# Altium schematic sheet Connectors.SchDoc
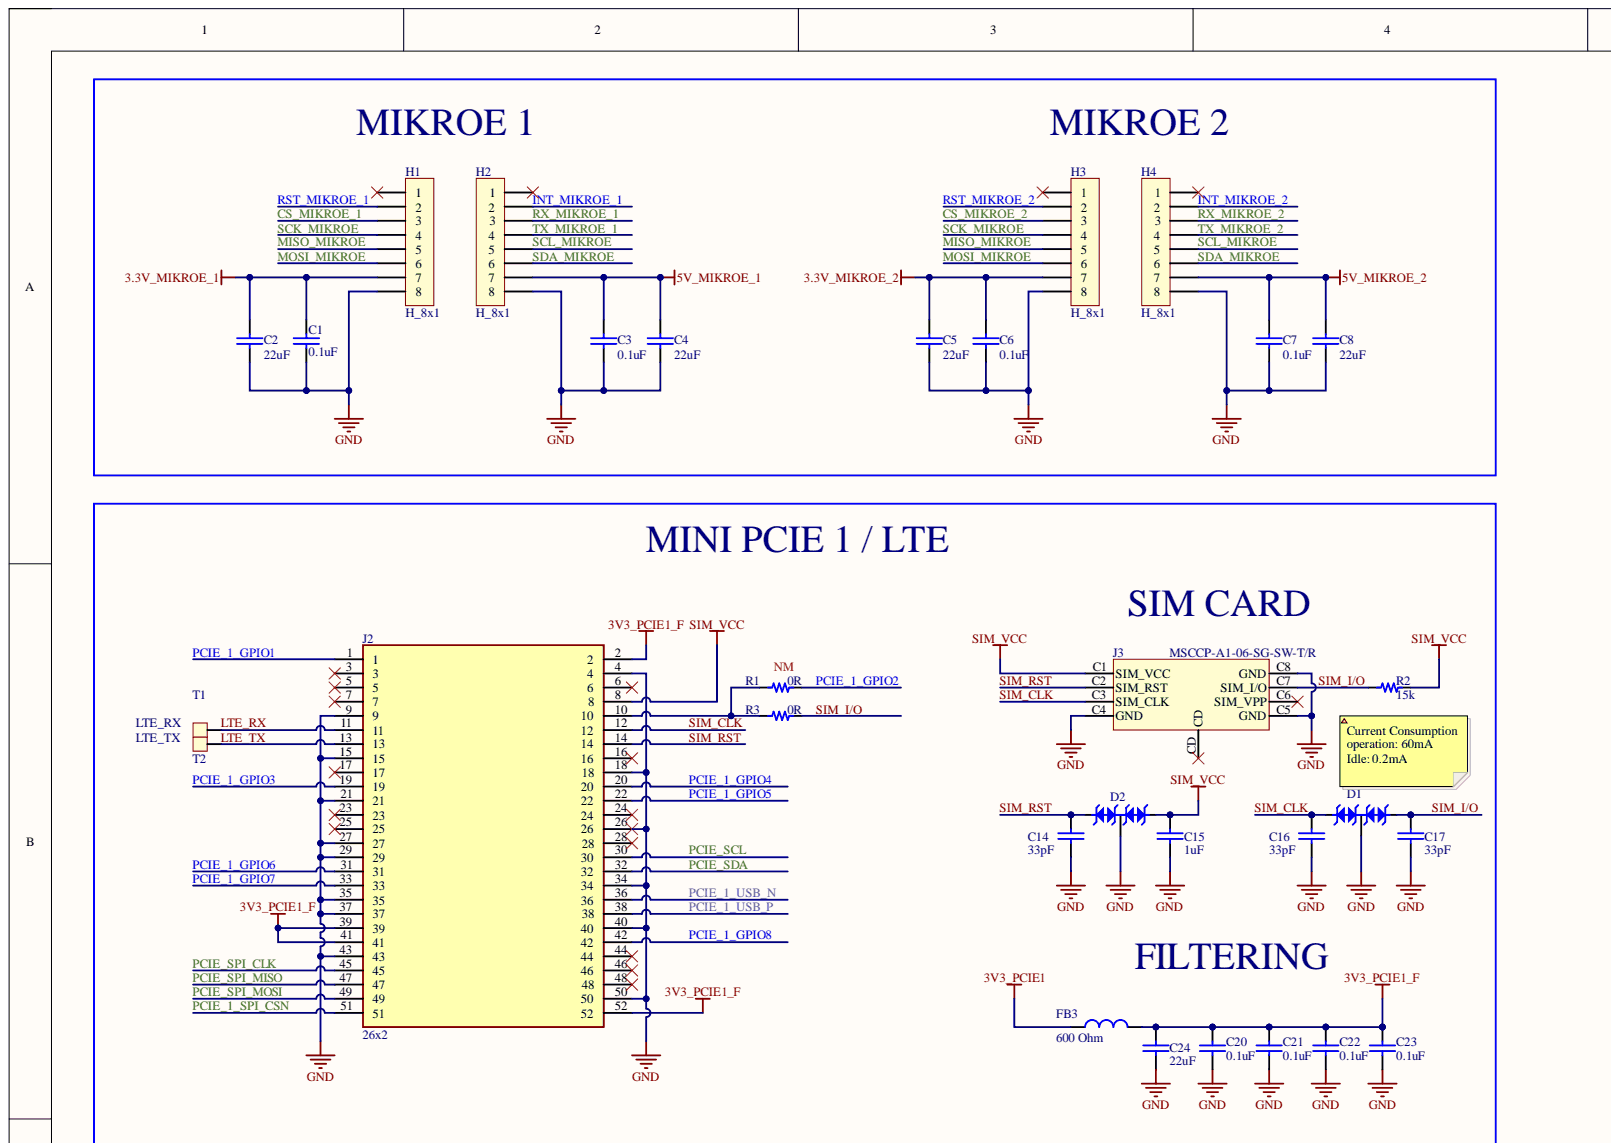
[diagram p0: Connectors.SchDoc, top left]
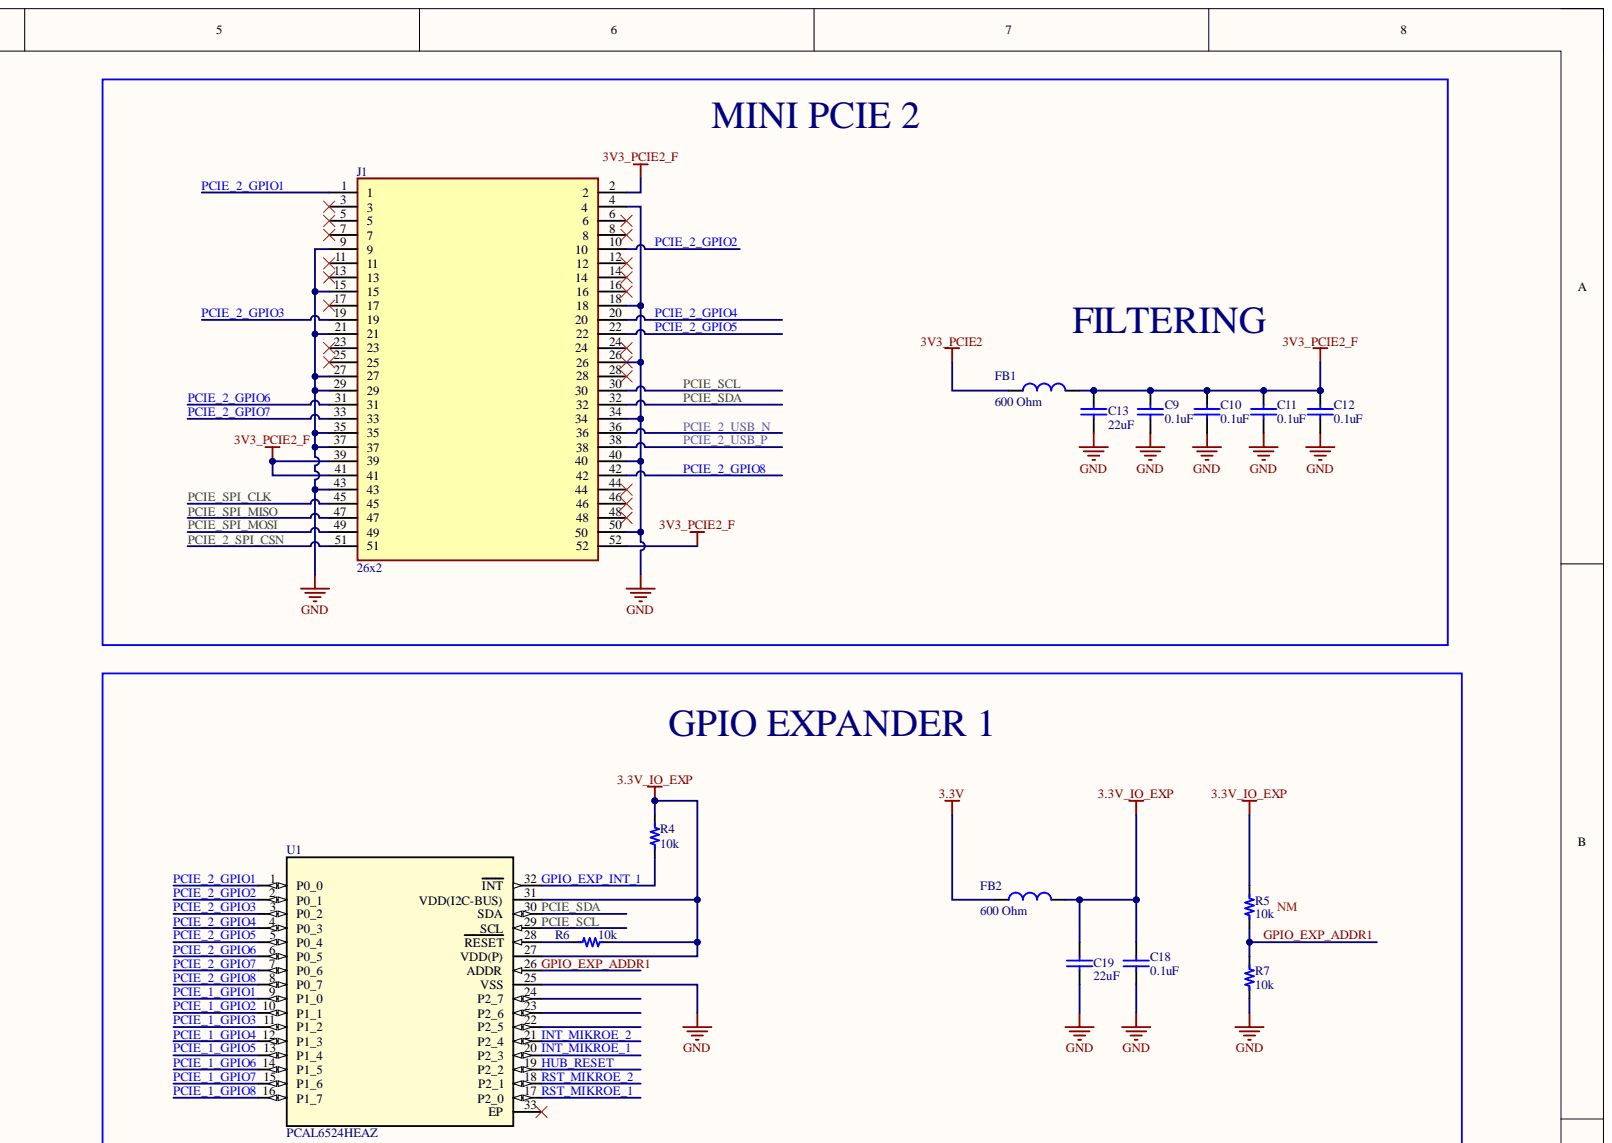
[diagram p1: Connectors.SchDoc, top right]
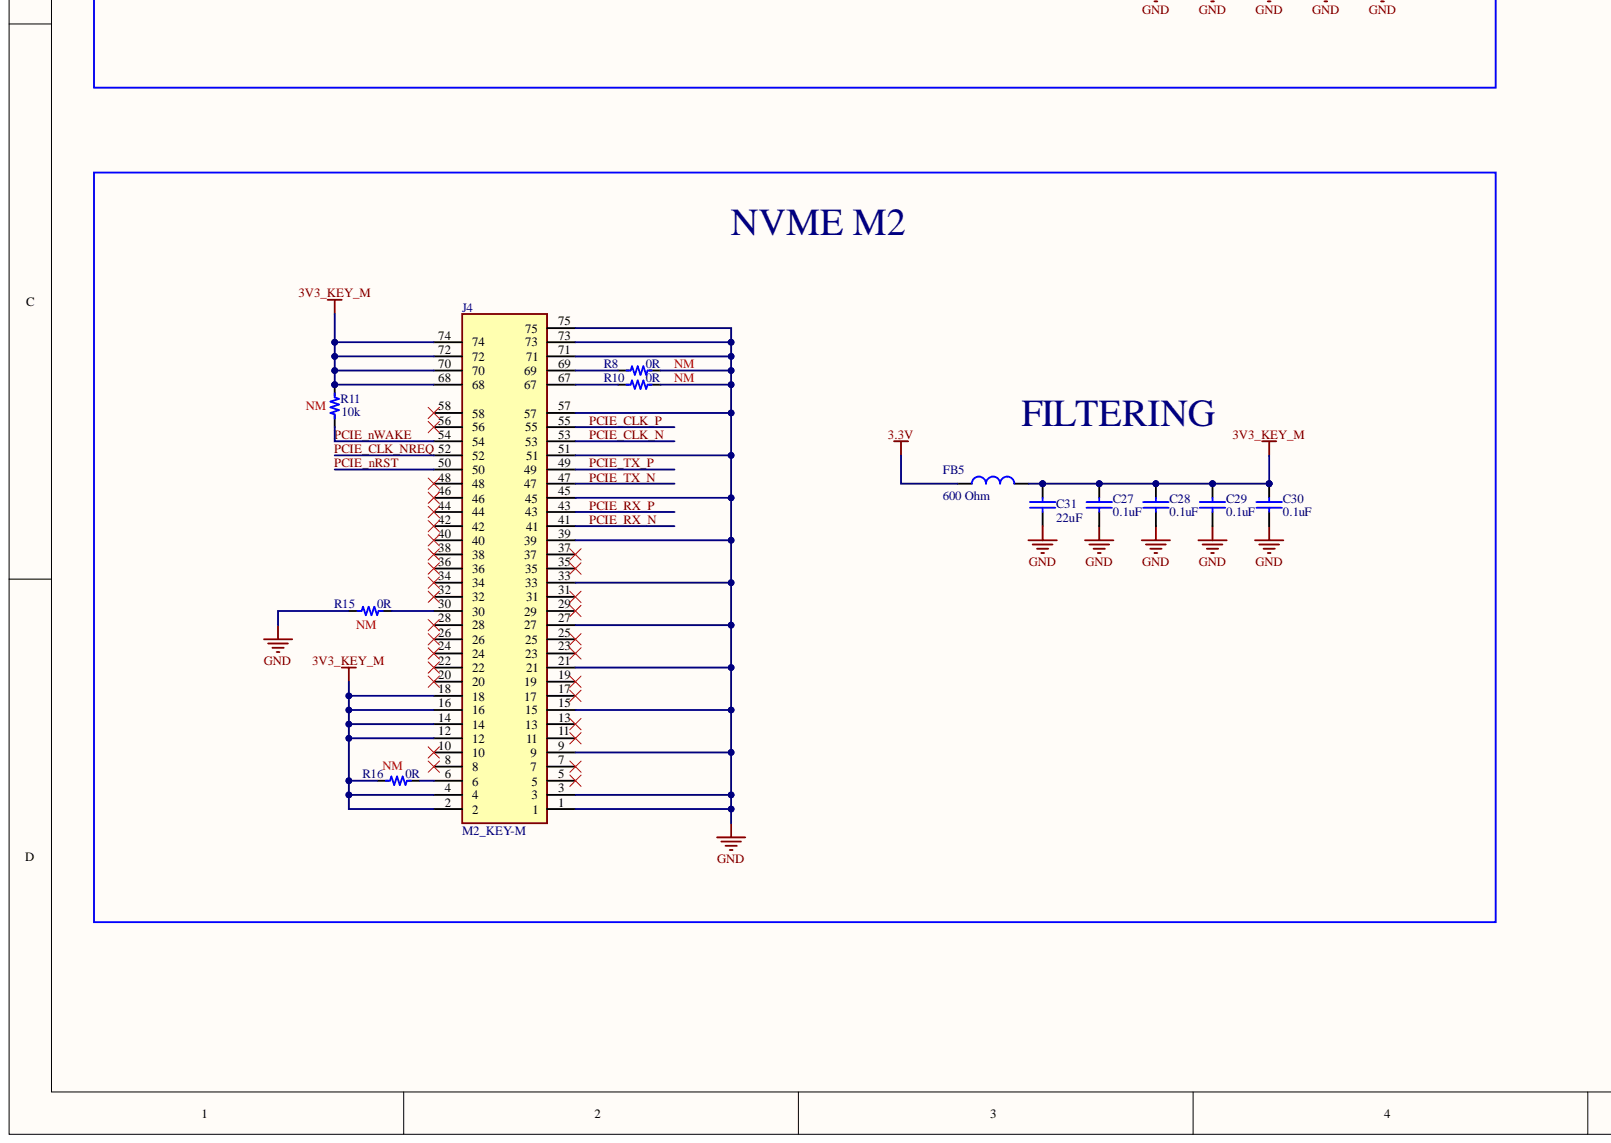
[diagram p2: Connectors.SchDoc, bottom left]
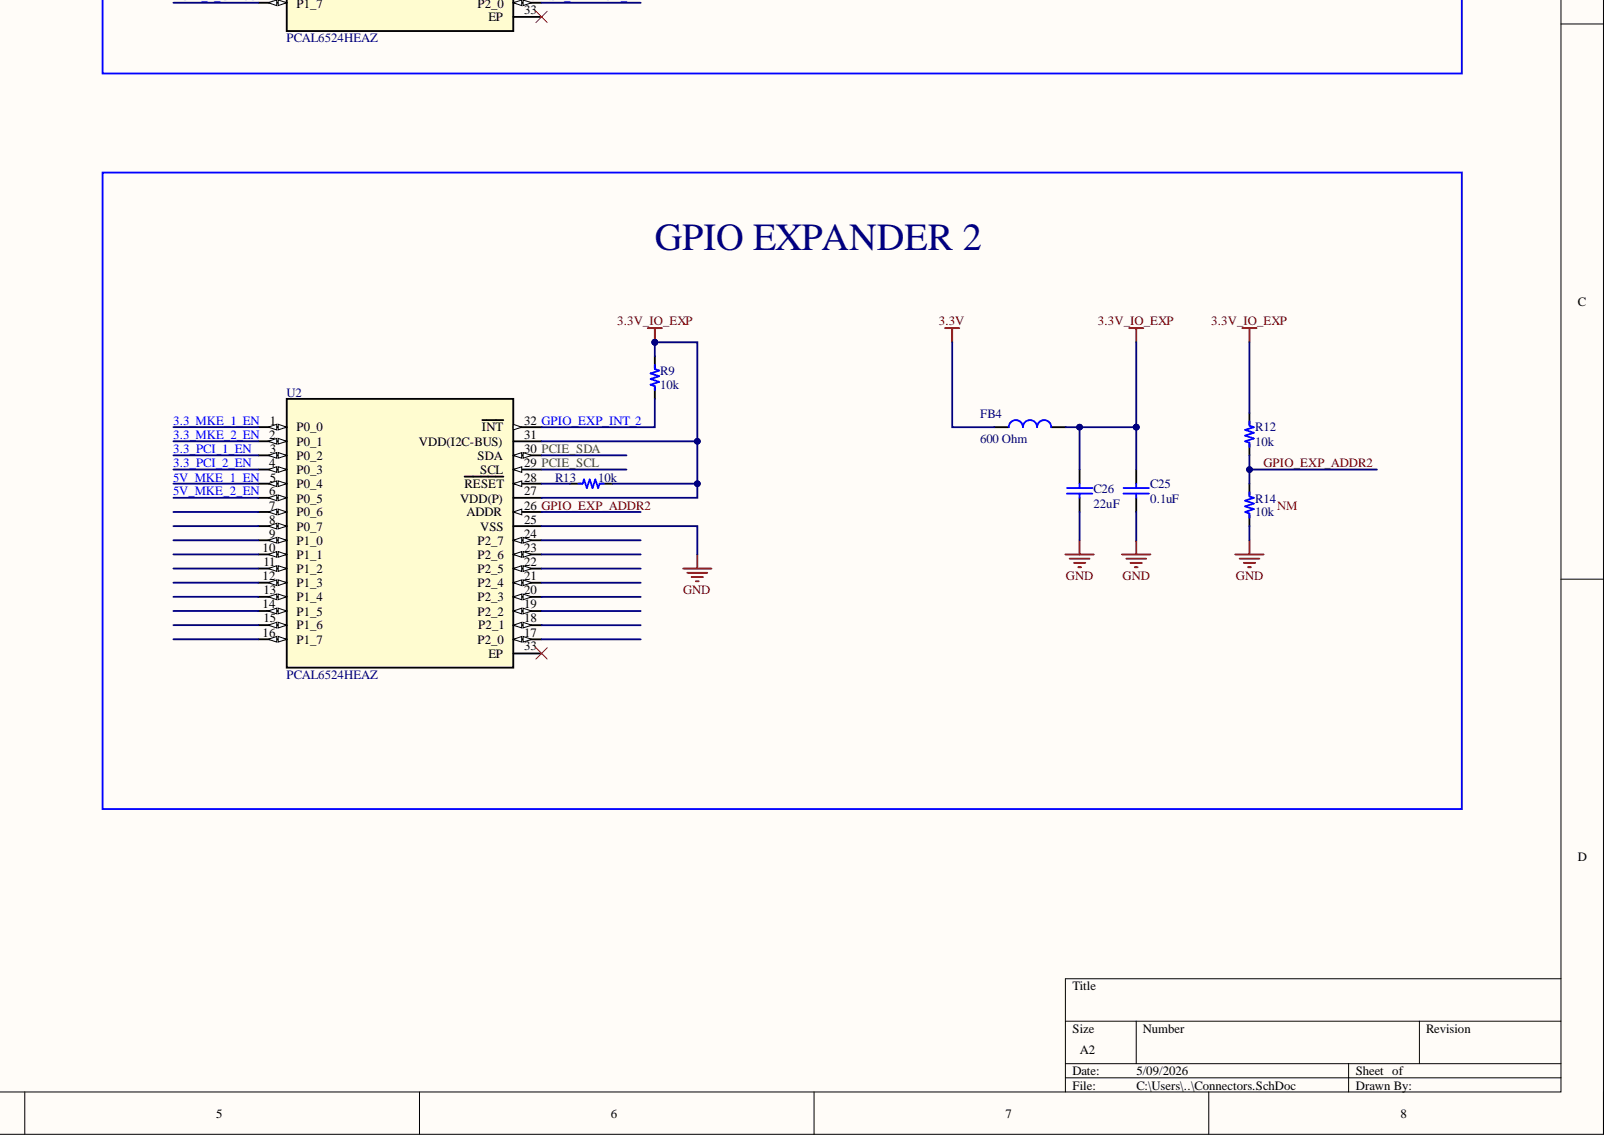
[diagram p3: Connectors.SchDoc, bottom right]

## Parts
C1  value 0.1uF  [0603_1.4mm]  (hidden comment: 0.1uF 100V)
C2  value 22uF  [0603_1.4mm]  (hidden comment: 22uF 10V)
C3  value 0.1uF  [0603_1.4mm]  (hidden comment: 0.1uF 100V)
C4  value 22uF  [0603_1.4mm]  (hidden comment: 22uF 10V)
C5  value 22uF  [0603_1.4mm]  (hidden comment: 22uF 10V)
C6  value 0.1uF  [0603_1.4mm]  (hidden comment: 0.1uF 100V)
C7  value 0.1uF  [0603_1.4mm]  (hidden comment: 0.1uF 100V)
C8  value 22uF  [0603_1.4mm]  (hidden comment: 22uF 10V)
C9  value 0.1uF  [0603]  (hidden comment: 0.1uF 50V)
C10  value 0.1uF  [0603]  (hidden comment: 0.1uF 50V)
C11  value 0.1uF  [0603]  (hidden comment: 0.1uF 50V)
C12  value 0.1uF  [0603]  (hidden comment: 0.1uF 50V)
C13  value 22uF  [0603_1.4mm]  (hidden comment: 22uF 6.3V)
C14  value 33pF  [0603_1.4mm]  (hidden comment: 33pF 50V)
C15  value 1uF  [0603_1.4mm]  (hidden comment: 1uF 25V)
C16  value 33pF  [0603_1.4mm]  (hidden comment: 33pF 50V)
C17  value 33pF  [0603_1.4mm]  (hidden comment: 33pF 50V)
C18  value 0.1uF  [0603]  (hidden comment: 0.1uF 50V)
C19  value 22uF  [0603_1.4mm]  (hidden comment: 22uF 6.3V)
C20  value 0.1uF  [0603]  (hidden comment: 0.1uF 50V)
C21  value 0.1uF  [0603]  (hidden comment: 0.1uF 50V)
C22  value 0.1uF  [0603]  (hidden comment: 0.1uF 50V)
C23  value 0.1uF  [0603]  (hidden comment: 0.1uF 50V)
C24  value 22uF  [0603_1.4mm]  (hidden comment: 22uF 6.3V)
C25  value 0.1uF  [0603]  (hidden comment: 0.1uF 50V)
C26  value 22uF  [0603_1.4mm]  (hidden comment: 22uF 6.3V)
C27  value 0.1uF  [0603]  (hidden comment: 0.1uF 50V)
C28  value 0.1uF  [0603]  (hidden comment: 0.1uF 50V)
C29  value 0.1uF  [0603]  (hidden comment: 0.1uF 50V)
C30  value 0.1uF  [0603]  (hidden comment: 0.1uF 50V)
C31  value 22uF  [0603_1.4mm]  (hidden comment: 22uF 6.3V)
D1  12V 17A  [SOT_23_3]  (value not drawn)
D2  12V 17A  [SOT_23_3]  (value not drawn)
FB1  value 600 Ohm  [1206]  (hidden comment: 600Ohm 2.5A)
FB2  value 600 Ohm  [1206]  (hidden comment: 600Ohm 2.5A)
FB3  value 600 Ohm  [1206]  (hidden comment: 600Ohm 2.5A)
FB4  value 600 Ohm  [1206]  (hidden comment: 600Ohm 2.5A)
FB5  value 600 Ohm  [1206]  (hidden comment: 600Ohm 2.5A)
H1  H_8x1  [H_8x1_TH_100mil]  (no BOM)
H2  H_8x1  [H_8x1_TH_100mil]  (no BOM)
H3  H_8x1  [H_8x1_TH_100mil]  (no BOM)
H4  H_8x1  [H_8x1_TH_100mil]  (no BOM)
J1  26x2  [CON_26X2_SMD_0.8MM]
J2  26x2  [CON_26X2_SMD_0.8MM]
J3  MSCCP-A1-06-SG-SW-T/R  [CON_MICRO_SIMCARD]
J4  M2_KEY-M  [CON_M2_KEY_M]
R1  value 0R  Usage: NM  [0603_1.4mm]  (hidden comment: 0R 1/10W)
R2  value 15k  [0603_1.4mm]  (hidden comment: 15k 1/10W)
R3  value 0R  [0603_1.4mm]  (hidden comment: 0R 1/10W)
R4  value 10k  [0603_1.4mm]  (hidden comment: 10k 1/10W)
R5  value 10k  Usage: NM  [0603_1.4mm]  (hidden comment: 10k 1/10W)
R6  value 10k  [0603_1.4mm]  (hidden comment: 10k 1/10W)
R7  value 10k  [0603_1.4mm]  (hidden comment: 10k 1/10W)
R8  value 0R  Usage: NM  [0603_1.4mm]  (hidden comment: 0R 1/10W)
R9  value 10k  [0603_1.4mm]  (hidden comment: 10k 1/10W)
R10  value 0R  Usage: NM  [0603_1.4mm]  (hidden comment: 0R 1/10W)
R11  value 10k  Usage: NM  [0603_1.4mm]  (hidden comment: 10k 1/10W)
R12  value 10k  [0603_1.4mm]  (hidden comment: 10k 1/10W)
R13  value 10k  [0603_1.4mm]  (hidden comment: 10k 1/10W)
R14  value 10k  Usage: NM  [0603_1.4mm]  (hidden comment: 10k 1/10W)
R15  value 0R  Usage: NM  [0603_1.4mm]  (hidden comment: 0R 1/10W)
R16  value 0R  Usage: NM  [0603_1.4mm]  (hidden comment: 0R 1/10W)
T1  LTE_RX  [TP_TH_30mil]  (no BOM)
T2  LTE_TX  [TP_TH_30mil]  (no BOM)
U1  PCAL6524HEAZ  [32-UFQFN_EP]
U2  PCAL6524HEAZ  [32-UFQFN_EP]

## Nets
3.3V: FB2.1 FB4.1 FB5.1
3.3V_IO_EXP: C18.1 C19.1 C25.1 C26.1 FB2.2 FB4.2 R4.1 R5.1 R6.2 R9.1 R12.1 R13.2 U1.27(VDD(P)) U1.31(VDD(I2C-BUS)) U2.27(VDD(P)) U2.31(VDD(I2C-BUS))
3.3V_MIKROE_1: C1.1 C2.2 H1.7
3.3V_MIKROE_2: C5.2 C6.1 H3.7
3V3_KEY_M: C27.1 C28.1 C29.1 C30.1 C31.1 FB5.2 J4.2 J4.4 J4.12 J4.14 J4.16 J4.18 J4.68 J4.70 J4.72 J4.74 R11.1 R16.1
3V3_PCIE1: FB3.1
3V3_PCIE1_F: C20.1 C21.1 C22.1 C23.1 C24.1 FB3.2 J2.2 J2.39 J2.41 J2.52
3V3_PCIE2: FB1.1
3V3_PCIE2_F: C9.1 C10.1 C11.1 C12.1 C13.1 FB1.2 J1.2 J1.39 J1.41 J1.52
5V_MIKROE_1: C3.1 C4.2 H2.7
5V_MIKROE_2: C7.1 C8.2 H4.7
GND: C1.2 C2.1 C3.2 C4.1 C5.1 C6.2 C7.2 C8.1 C9.2 C10.2 C11.2 C12.2 C13.2 C14.2 C15.2 C16.2 C17.2 C18.2 C19.2 C20.2 C21.2 C22.2 C23.2 C24.2 C25.2 C26.2 C27.2 C28.2 C29.2 C30.2 C31.2 D1.3 D2.3 H1.8 H2.8 H3.8 H4.8 J1.4 J1.9 J1.15 J1.18 J1.21 J1.26 J1.27 J1.29 J1.34 J1.35 J1.37 J1.40 J1.43 J1.50 J2.4 J2.9 J2.15 J2.18 J2.21 J2.26 J2.27 J2.29 J2.34 J2.35 J2.37 J2.40 J2.43 J2.50 J3.C4(GND) J3.C5(GND) J3.C8(GND) J4.1 J4.3 J4.9 J4.15 J4.21 J4.27 J4.33 J4.39 J4.45 J4.51 J4.57 J4.71 J4.73 J4.75 R7.2 R8.2 R10.2 R14.2 R15.1 U1.25(VSS) U2.25(VSS)
SIM_VCC: C15.1 D2.2 J2.8 J3.C1(SIM_VCC) R2.1
3.3_MKE_1_EN: U2.1(P0_0)
3.3_MKE_2_EN: U2.2(P0_1)
3.3_PCI_1_EN: U2.3(P0_2)
3.3_PCI_2_EN: U2.4(P0_3)
5V_MKE_1_EN: U2.5(P0_4)
5V_MKE_2_EN: U2.6(P0_5)
CS_MIKROE_1: H1.3
CS_MIKROE_2: H3.3
GPIO_EXP_ADDR1: R5.2 R7.1 U1.26(ADDR)
GPIO_EXP_ADDR2: R12.2 R14.1 U2.26(ADDR)
GPIO_EXP_INT_1: R4.2 U1.32(INT)
GPIO_EXP_INT_2: R9.2 U2.32(INT)
HUB_RESET: U1.19(P2_2)
INT_MIKROE_1: H2.2 U1.20(P2_3)
INT_MIKROE_2: H4.2 U1.21(P2_4)
LTE_RX: J2.11 T1.1
LTE_TX: J2.13 T2.1
MISO_MIKROE: H1.5 H3.5
MOSI_MIKROE: H1.6 H3.6
PCIE_1_GPIO1: J2.1 U1.9(P1_0)
PCIE_1_GPIO2: R1.2 U1.10(P1_1)
PCIE_1_GPIO3: J2.19 U1.11(P1_2)
PCIE_1_GPIO4: J2.20 U1.12(P1_3)
PCIE_1_GPIO5: J2.22 U1.13(P1_4)
PCIE_1_GPIO6: J2.31 U1.14(P1_5)
PCIE_1_GPIO7: J2.33 U1.15(P1_6)
PCIE_1_GPIO8: J2.42 U1.16(P1_7)
PCIE_1_SPI_CSN: J2.51
PCIE_1_USB_N: J2.36
PCIE_1_USB_P: J2.38
PCIE_2_GPIO1: J1.1 U1.1(P0_0)
PCIE_2_GPIO2: J1.10 U1.2(P0_1)
PCIE_2_GPIO3: J1.19 U1.3(P0_2)
PCIE_2_GPIO4: J1.20 U1.4(P0_3)
PCIE_2_GPIO5: J1.22 U1.5(P0_4)
PCIE_2_GPIO6: J1.31 U1.6(P0_5)
PCIE_2_GPIO7: J1.33 U1.7(P0_6)
PCIE_2_GPIO8: J1.42 U1.8(P0_7)
PCIE_2_SPI_CSN: J1.51
PCIE_2_USB_N: J1.36
PCIE_2_USB_P: J1.38
PCIE_CLK_N: J4.53
PCIE_CLK_NREQ: J4.52
PCIE_CLK_P: J4.55
PCIE_nRST: J4.50
PCIE_nWAKE: J4.54 R11.2
PCIE_RX_N: J4.41
PCIE_RX_P: J4.43
PCIE_SCL: J1.30 J2.30 U1.29(SCL) U2.29(SCL)
PCIE_SDA: J1.32 J2.32 U1.30(SDA) U2.30(SDA)
PCIE_SPI_CLK: J1.45 J2.45
PCIE_SPI_MISO: J1.47 J2.47
PCIE_SPI_MOSI: J1.49 J2.49
PCIE_TX_N: J4.47
PCIE_TX_P: J4.49
RST_MIKROE_1: H1.2 U1.17(P2_0)
RST_MIKROE_2: H3.2 U1.18(P2_1)
RX_MIKROE_1: H2.3
RX_MIKROE_2: H4.3
SCK_MIKROE: H1.4 H3.4
SCL_MIKROE: H2.5 H4.5
SDA_MIKROE: H2.6 H4.6
SIM_CLK: C16.1 D1.1 J2.12 J3.C3(SIM_CLK)
SIM_I/O: C17.1 D1.2 J3.C7(SIM_I/O) R2.2 R3.2
SIM_RST: C14.1 D2.1 J2.14 J3.C2(SIM_RST)
TX_MIKROE_1: H2.4
TX_MIKROE_2: H4.4
NetJ2_10: J2.10 R1.1 R3.1
NetJ4_6: J4.6 R16.2
NetJ4_30: J4.30 R15.2
NetJ4_67: J4.67 R10.1
NetJ4_69: J4.69 R8.1
NetR6_1: R6.1 U1.28(RESET)
NetR13_1: R13.1 U2.28(RESET)

Not connected: H1 1; H2 1; H3 1; H4 1; J1 3 5 6 7 8 11 12 13 14 16 17 23 24 25 28 44 46 48; J2 3 5 6 7 16 17 23 24 25 28 44 46 48; J3 C6(SIM_VPP) CD; J4 5 7 8 10 11 13 17 19 20 22 23 24 25 26 28 29 31 32 34 35 36 37 38 40 42 44 46 48 56 58; U1 22(P2_5) 23(P2_6) 24(P2_7) 33(EP); U2 7(P0_6) 8(P0_7) 9(P1_0) 10(P1_1) 11(P1_2) 12(P1_3) 13(P1_4) 14(P1_5) 15(P1_6) 16(P1_7) 17(P2_0) 18(P2_1) 19(P2_2) 20(P2_3) 21(P2_4) 22(P2_5) 23(P2_6) 24(P2_7) 33(EP)

## Library data of the parts
C1 C3 C6 C7 = Cap_0.1uF_0603_100V: CAP CER 0.1UF 100V X7R 0603; Manufacturer: Samsung Electro-Mechanics; Manufacturer Part Number: CL10B104KC8NNNC; Component Kind: Standard; ComponentLink1Description: Digi-Key; ComponentLink1URL: https://www.digikey.com/en/products/detail/samsung-electro-mechanics/CL10B104KC8NNNC/5961291; Last modified date: 20250321; Library Name: Capacitors.IntLib; Supplier 1: Digi-Key; Supplier Part Number 1: 1276-6807-1-ND
C2 C4 C5 C8 = Cap_22uF_0603_10V: CAP CER 22UF 10V X5R 0603; Manufacturer: Murata Electronics North America; Manufacturer Part Number: GRM188R61A226ME15D; Component Kind: Standard; ComponentLink1Description: Digi-key; ComponentLink1URL: https://www.digikey.com/product-detail/en/murata-electronics-north-america/GRM188R61A226ME15D/490-10476-1-ND/5026394; Last modified date: 20250321; Library Name: Capacitors.IntLib; Supplier 1: Digi-Key; Supplier Part Number 1: 490-10476-1-ND
C9-C12 C18 C20-C23 C25 C27-C30 = Cap_0.1uF_0603_50V: CAP CER 0.1UF 50V X7R 0603; Manufacturer: Samsung Electro-Mechanics; Manufacturer Part Number: CL10B104JB8NNNC; Titoma stock: TD-TE-Reel; Component Kind: Standard; ComponentLink1Description: Digi-key; ComponentLink1URL: https://www.digikey.com/en/products/detail/samsung-electro-mechanics/CL10B104JB8NNNC/3886691?s=N4IgTCBcDaIIxgOwDYC0cAMBmL7UDkAREAXQF8g; Last modified date: 20210309; Library Name: Capacitors.IntLib; Supplier 1: Digi-Key; Supplier Part Number 1: 1276-1033-1-ND; Last modified by: C-D Viveros
C13 C19 C24 C26 C31 = Cap_22uF_0603_6.3V: CAP CER 22UF 6.3V X5R 0603; Manufacturer: Murata; Manufacturer Part Number: GRM188R60J226MEA0D; Component Kind: Standard; ComponentLink1Description: Digi-key; ComponentLink1URL: https://www.digikey.com/products/en?keywords=490-7611-1-ND; Last modified date: 20200505; Library Name: Capacitors.IntLib; Supplier 1: Digi-Key; Supplier Part Number 1: 490-7611-1-ND; Last modified by: N-A.Potosi
C14 C16 C17 = Cap_33pF_0603_50V: CAP CER 33PF 50V C0G/NP0 0603; Manufacturer: Walsin Technologies; Manufacturer Part Number: 0603N330J500CT; Titoma stock: TD-TE-Reel; Component Kind: Standard; ComponentLink1Description: Digi-Key; ComponentLink1URL: https://www.digikey.com/en/products/detail/walsin-technology-corporation/0603N330J500CT/6707550?s=N4IgTCBcDaIIxgJxgLRwGwBYCsaUDkAREAXQF8g; Last modified date: 20210512; Library Name: Capacitors.IntLib; Supplier 1: Digi-Key; Supplier Part Number 1: 1292-1645-1-ND; Last modified by: C-A.Serna.A
C15 = Cap_1uF_0603_25V: CAP CER 1UF 25V X7R 0603; Manufacturer: Samsung Electro-Mechanics; Manufacturer Part Number: CL10B105KA8VPNC; Titoma stock: TD-TE-Reel; Component Kind: Standard; ComponentLink1Description: Digi-Key; ComponentLink1URL: https://www.digikey.com/en/products/detail/samsung-electro-mechanics/CL10B105KA8VPNC/5961101?s=N4IgTCBcDaIIxgOwDYC0zl0auqByAIiALoC%2BQA; Last modified date: 20210511; Library Name: Capacitors.IntLib; Supplier 1: Digi-Key; Supplier Part Number 1: 1276-6617-1-ND; Last modified by: C-A.Serna.A
D1 D2 = TVS_12V_2CH-BI_SOT-23-3: TVS DIODE 12V/7V 26V/12V SOT23-3; Manufacturer: Semtech Corporation; Manufacturer Part Number: SM712.TCT; Component Kind: Standard; ComponentLink1Description: Digi-Key; ComponentLink1URL: https://www.digikey.com/en/products/detail/semtech-corporation/SM712-TCT/1000933?s=N4IgTCBcDaIMoFkDsBGMBhAKgWgHIBEQBdAXyA; Last modified date: 20210208; Library Name: Circuit_protection.IntLib; Supplier 1: Digi-Key; Supplier Part Number 1: SM712CT-ND; Last modified by: C-D Viveors
FB1-FB5 = FB_600_1206_2.5A: FERRITE BEAD 600 OHM 1206 1LN; Manufacturer: Würth Elektronik; Manufacturer Part Number: 742792118; Component Kind: Standard; ComponentLink1Description: Digi-key; ComponentLink1URL: https://www.digikey.com/products/en?keywords=732-4653-1-ND; Last modified date: 20210806; Library Name: Filters.IntLib; Supplier 1: Digi-key; Supplier Part Number 1: 732-4653-1-ND; Last modified by: N-A.Potosi
H1-H4 = H_Male_8x1_TH_100mil: Debugging male pin; Last modified date: 20250321; Library Name: Connectors.IntLib
J1 J2 = Con_26x2_SMD_0.8mm_PCIEXP_MINI: CONN PCI EXP MINI FEMALE 52POS; Manufacturer: TE Connectivity AMP Connectors; Manufacturer Part Number: 1775862-2; Component Kind: Standard; ComponentLink1Description: Digikey; ComponentLink1URL: https://www.digikey.com/en/products/detail/te-connectivity-amp-connectors/1775862-2/5430585?s=N4IgTCBcDaIIIEYAsYwHYDMBhAKgWgDkAREAXQF8g; Last modified date: 20250321; Library Name: Connectors.IntLib; Supplier 1: Digi-key; Supplier Part Number 1: A142273CT-ND
J3 = Con_Micro_SimCard: MINI SIM CARD CONNECTOR; Manufacturer: Adam Tech; Component Kind: Standard; ComponentLink1Description: Digikey; ComponentLink1URL: https://www.digikey.com/en/products/detail/adam-tech/MSCCP-A1-06-SG-SW-T-R/11689344?s=N4IgTCBcDa4AwFYDsBaAsgZQMJYAooEEBGFOANhQwHFKB1FAFQHoAlLBlAOQBEQBdAL5A; Last modified date: 20210723; Library Name: Connectors.IntLib; Manufacturer Part Number: MSCCP-A1-06-SG-SW-T/R; Supplier 1: Digikey; Supplier Part Number 1: 2057-MSCCP-A1-06-SG-SW-T/RCT-ND; Last modified by: J-E.Pachon
J4 = CON_M2_KEY_M: M.2 R/A M KEY 3.20H; Manufacturer: Amphenol ICC (FCI); Manufacturer Part Number: MDT320M01004; Component Kind: Standard; Last modified date: 20260406; Library Name: Connectors.IntLib; Supplier 1: Digi-Key; Supplier Part Number 1: 609-MDT320M01004CT-ND
R1 R3 R8 R10 R15 R16 = Res_0R_0603_1/10W: RES SMD 0 OHM JUMPER 1/10W 0603; Manufacturer: Panasonic Electronic Components; Manufacturer Part Number: ERJ-3GEY0R00V; Component Kind: Standard; ComponentLink1Description: Digi-key; ComponentLink1URL: https://www.digikey.com/products/en?keywords=P0.0GCT-ND; Last modified date: 20250321; Supplier 1: Digi-Key; Supplier Part Number 1: P0.0GCT-ND; Library Name: Resistors-potenciometers.IntLib
R2 = Res_15k_0603_1/10W: RES SMD 15K OHM 1% 1/10W 0603; Manufacturer: Yageo; Manufacturer Part Number: RC0603FR-0715KL; Titoma stock: TD-TE-Reel; Component Kind: Standard; ComponentLink1Description: Digi-Key; ComponentLink1URL: https://www.digikey.com/product-detail/en/yageo/RC0603FR-0715KL/311-15.0KHRTR-ND/726953; Last modified date: 20210513; Supplier 1: Digi-Key; Supplier Part Number 1: 311-15.0KHRCT-ND; Last modified by: J-E.Pachon; Library Name: Resistors-potenciometers.IntLib
R4-R7 R9 R11-R14 = Res_10k_0603_1/10W: RES SMD 10K OHM 1% 1/10W 0603; Manufacturer: Samsung Electro-Mechanics; Manufacturer Part Number: RC1608F103CS; Component Kind: Standard; ComponentLink1Description: Digi-key; ComponentLink1URL: https://www.digikey.com/products/en?keywords=1276-3485-1-ND; Last modified date: 20250321; Supplier 1: Digi-Key; Supplier Part Number 1: 1276-3485-1-ND; Library Name: Resistors-potenciometers.IntLib
T1 T2 = TP_TH_30mil: Test point 30mil hole size; Last modified date: 20190225; Library Name: Connectors.IntLib; Last modified by: C-A.Serna.A
U1 U2 = IC_I/O_EXPANDER_24GPIO_HUQFN: IC XPNDR 1MHZ I2C 32HUQFN; Manufacturer: NXP USA Inc.; Component Kind: Standard; ComponentLink1Description: Digi-key; ComponentLink1URL: https://www.digikey.com/en/products/detail/nxp-usa-inc/PCAL6524HEAZ/5823391; Last modified date: 20260505; Library Name: ICs.IntLib; Manufacturer Part Number: PCAL6524HEAZ; Supplier 1: Digi-Key; Supplier Part Number 1: 568-12879-1-ND
